# Altium schematic sheet 1_MCU.SchDoc(U_1_MCU)
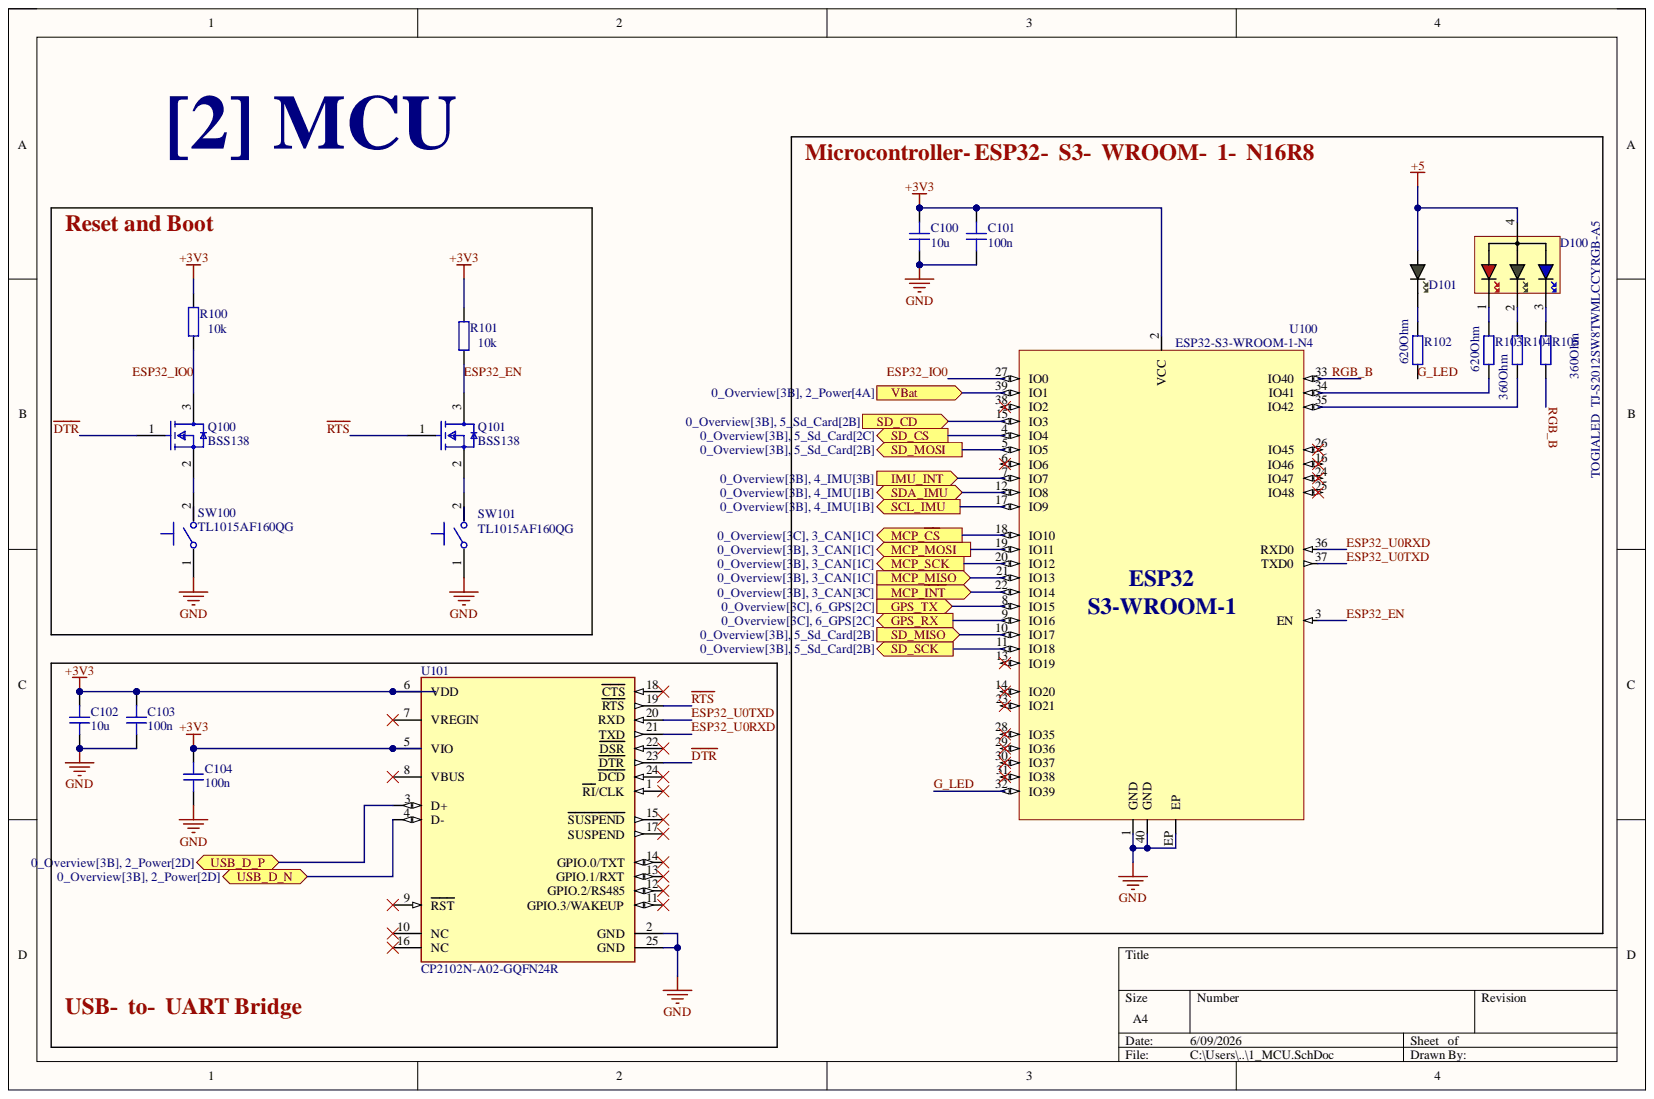
[diagram p0: 1_MCU.SchDoc]

## Parts
C100  10u  [CAP_0805]
C101  100n  [CAP_0603]
C102  10u  [CAP_0805]
C103  100n  [CAP_0603]
C104  100n  [CAP_0603]
D100  TOGIALED  TJ-S2012SW8TWMLCCYRGB-A5  [150080M153000]
D101  GREEN  [LED_0603_GREEN]  (value not drawn)
Q100  BSS138  [FP-318-08-IPC_B]
Q101  BSS138  [FP-318-08-IPC_B]
R100  10k  [RES_0603]
R101  10k  [RES_0603]
R102  620Ohm  [RES_0603]
R103  620Ohm  [RES_0603]
R104  360Ohm  [RES_0603]
R105  360Ohm  [RES_0603]
SW100  TL1015AF160QG  [FP-TL1015AF160QG-MFG]
SW101  TL1015AF160QG  [FP-TL1015AF160QG-MFG]
U100  ESP32-S3-WROOM-1-N4  [ESP32-S3-WROOM-1]
U101  CP2102N-A02-GQFN24R  [FP-CP2102N-A02-GQFN24R-MFG]

## Ports
GPS_RX, GPS_TX, IMU_INT, MCP_MISO, MCP_MOSI, MCP_SCK, MCP_~{CS}, MCP_~{INT}, SCL_IMU, SD_CD, SD_CS, SD_MISO, SD_MOSI, SD_SCK, SDA_IMU, USB_D_N, USB_D_P, VBat

## Nets
+3V3: C100.2 C101.1 C102.2 C103.1 C104.1 R100.2 R101.2 U100.2(VCC) U101.5(VIO) U101.6(VDD)
+5: D100.4 D101.A
GND: C100.1 C101.2 C102.1 C103.2 C104.2 SW100.1 SW101.1 U100.1(GND) U100.40(GND) U100.EP U101.2(GND) U101.25(GND)
~{DTR}: Q100.1 U101.23(DTR)
ESP32_EN: Q101.3 R101.1 U100.3(EN)
ESP32_IO0: Q100.3 R100.1 U100.27(IO0)
ESP32_U0RXD: U100.36(RXD0) U101.21(TXD)
ESP32_U0TXD: U100.37(TXD0) U101.20(RXD)
G_LED: R102.1 U100.32(IO39)
GPS_RX: U100.9(IO16) ; port GPS_RX
GPS_TX: U100.8(IO15) ; port GPS_TX
IMU_INT: U100.7(IO7) ; port IMU_INT
MCP_~{CS}: U100.18(IO10) ; port MCP_~{CS}
MCP_~{INT}: U100.22(IO14) ; port MCP_~{INT}
MCP_MISO: U100.21(IO13) ; port MCP_MISO
MCP_MOSI: U100.19(IO11) ; port MCP_MOSI
MCP_SCK: U100.20(IO12) ; port MCP_SCK
~{RTS}: Q101.1 U101.19(RTS)
RGB_B: R105.1 U100.33(IO40)
SCL_IMU: U100.17(IO9) ; port SCL_IMU
SD_CD: U100.15(IO3) ; port SD_CD
SD_CS: U100.4(IO4) ; port SD_CS
SD_MISO: U100.10(IO17) ; port SD_MISO
SD_MOSI: U100.5(IO5) ; port SD_MOSI
SD_SCK: U100.11(IO18) ; port SD_SCK
SDA_IMU: U100.12(IO8) ; port SDA_IMU
USB_D_N: U101.4(D-) ; port USB_D_N
USB_D_P: U101.3(D+) ; port USB_D_P
VBat: U100.39(IO1) ; port VBat
NetD100_1: D100.1 R103.2
NetD100_2: D100.2 R104.2
NetD100_3: D100.3 R105.2
NetD101_K: D101.K R102.2
NetQ100_2: Q100.2 SW100.2
NetQ101_2: Q101.2 SW101.2
NetR103_1: R103.1 U100.34(IO41)
NetR104_1: R104.1 U100.35(IO42)
NetU100_6: U100.6(IO6)
NetU100_13: U100.13(IO19)
NetU100_14: U100.14(IO20)
NetU100_16: U100.16(IO46)
NetU100_23: U100.23(IO21)
NetU100_24: U100.24(IO47)
NetU100_25: U100.25(IO48)
NetU100_26: U100.26(IO45)
NetU100_28: U100.28(IO35)
NetU100_29: U100.29(IO36)
NetU100_30: U100.30(IO37)
NetU100_31: U100.31(IO38)
NetU100_38: U100.38(IO2)
NetU101_1: U101.1(RI/CLK)
NetU101_7: U101.7(VREGIN)
NetU101_8: U101.8(VBUS)
NetU101_9: U101.9(RST)
NetU101_10: U101.10(NC)
NetU101_11: U101.11(GPIO.3/WAKEUP)
NetU101_12: U101.12(GPIO.2/RS485)
NetU101_13: U101.13(GPIO.1/RXT)
NetU101_14: U101.14(GPIO.0/TXT)
NetU101_15: U101.15(SUSPEND)
NetU101_16: U101.16(NC)
NetU101_17: U101.17(SUSPEND)
NetU101_18: U101.18(CTS)
NetU101_22: U101.22(DSR)
NetU101_24: U101.24(DCD)

## Library data of the parts
C100 C102 = CAP_0805: Capacitor SMD 0805; Component Kind: Standard; Library Name: Schematic-Lib-PhilsLab.SchLib
C101 C103 C104 = CAP_0603: Capacitor SMD 0603; Component Kind: Standard; Library Name: Schematic-Lib-PhilsLab.SchLib
D100 = 150080M153000: RGB LED Common Anode SMD Diffused 0805 Top View; Manufacturer: Wurth; Component Kind: Standard; Distributor Link: https://www.mouser.de/ProductDetail/Wurth-Elektronik/150080M153000?qs=5aG0NVq1C4wI5cZ2wabu5A%3D%3D; Manufacturer Part Number: 150080M153000; Library Name: Schematic-Lib-PhilsLab.SchLib
D101 = LED_0603_GREEN: LED 0603 GREEN SMD; Component Kind: Standard; Library Name: Schematic-Lib-PhilsLab.SchLib
Q100 Q101 = CMP-07173-000101-1: MOSFET N-CH 50V 220MA SOT-23; Drain to Source Resistance: 3.5R; Element Configuration: Single; Fall Time: 9ns; Gate to Source Voltage (Vgs): 20V; Input Capacitance: 27pF; Max Power Dissipation: 360mW; Nominal Vgs: 1.3V; Number of Elements: 1; On-State Resistance: 6R; Turn-Off Delay Time: 20ns; Turn-On Delay Time: 2.5ns; Case/Package: SOT-23; Component Kind: Standard; Contact Plating: Tin; Continuous Drain Current (ID): 220mA; Current Rating: 220mA; Drain to Source Breakdown Voltage: 50V; Drain to Source Voltage (Vdss): 50V; Dual Supply Voltage: 50V; Height: 0.93mm; Lead Free: Lead Free; Length: 2.92mm; Library Name: Altium Content Vault; Max Operating Temperature: 150°C; Min Operating Temperature: -55°C; Mount: Surface Mount; Number of Pins: 3; Package Quantity: 1; Packaging: Tape and Reel; Power Dissipation: 360mW; Radiation Hardening: No; Rds On Max: 3.5R; REACH SVHC: No SVHC; Rise Time: 9ns; Termination: SMD/SMT; Threshold Voltage: 1.3V; Voltage Rating (DC): 50V; Weight: 0.03g; Width: 1.3mm
R100-R105 = RES_0603: Resistor SMD 0603; Component Kind: Standard; Library Name: Schematic-Lib-PhilsLab.SchLib
SW100 SW101 = CMP-17879-000003-1: SWITCH TACTILE SPST-NO 0.05A 12V; Actuator Length: 0.5mm; Actuator Material: Nylon; Actuator Type: Standard; Contact Current Rating: 50mA; Contact Resistance: 100mR; Electrical Life: 50000Cycles; Illumination Type: Non-Illuminated; Mechanical Life: 200000Cycles; Operating Force: 160gf; Switch Function: Off-Mom; Switch Travel: 0.2mm; Throw Configuration: SPST; Case/Package: SMD/SMT; Color: Beige; Component Kind: Standard; Contact Material: Silver; Contact Plating: Silver; Current Rating: 50mA; Lead Free: Lead Free; Library Name: Altium Content Vault; Max Operating Temperature: 70°C; Max Voltage Rating (DC): 12V; Min Operating Temperature: -20°C; Mount: Surface Mount; Orientation: Straight; Package Quantity: 1; Packaging: Tape and Reel; REACH SVHC: No SVHC; Termination: Gull Wing; Voltage Rating (DC): 12V
U100 = ESP32-S3-WROOM-1-N4: 2.4 GHz Wi-Fi (802.11 b/g/n) and Bluetooth 5 (LE) Module ESP32-S3, 4MB Flash, on-board PCB antenna); Manufacturer: Espressif; Component Kind: Standard; Distributor Link: https://www.mouser.de/ProductDetail/Espressif-Systems/ESP32-S3-WROOM-1-N4?qs=Li%252BoUPsLEnsO%2FkiJOUK0FQ%3D%3D; Manufacturer Part Number: ESP32-S3-WROOM-1-N4; Library Name: Schematic-Lib-PhilsLab.SchLib
U101 = CMP-08107-000009-2: IC USB TO UART BRIDGE QFN24; Manufacturer Lifecycle Status: FULL PRODUCTION; NexarPartId: 99772192; Interface: I2C, RS-485, UART, USB; Max Junction Temperature (Tj): 105°C; Max Supply Voltage: 3.6V; Min Supply Voltage: 1.71V; Number of Digital IO: 4; Ambient Temperature Range High: 85°C; Component Kind: Standard; Height: 800µm; Library Name: Altium Content Vault; Lifecycle Status: Production; Max Operating Temperature: 85°C; Min Operating Temperature: -40°C; Schedule B: 8542390000, 8542390000
